# Altium schematic sheet STLink.SchDoc(STLink)
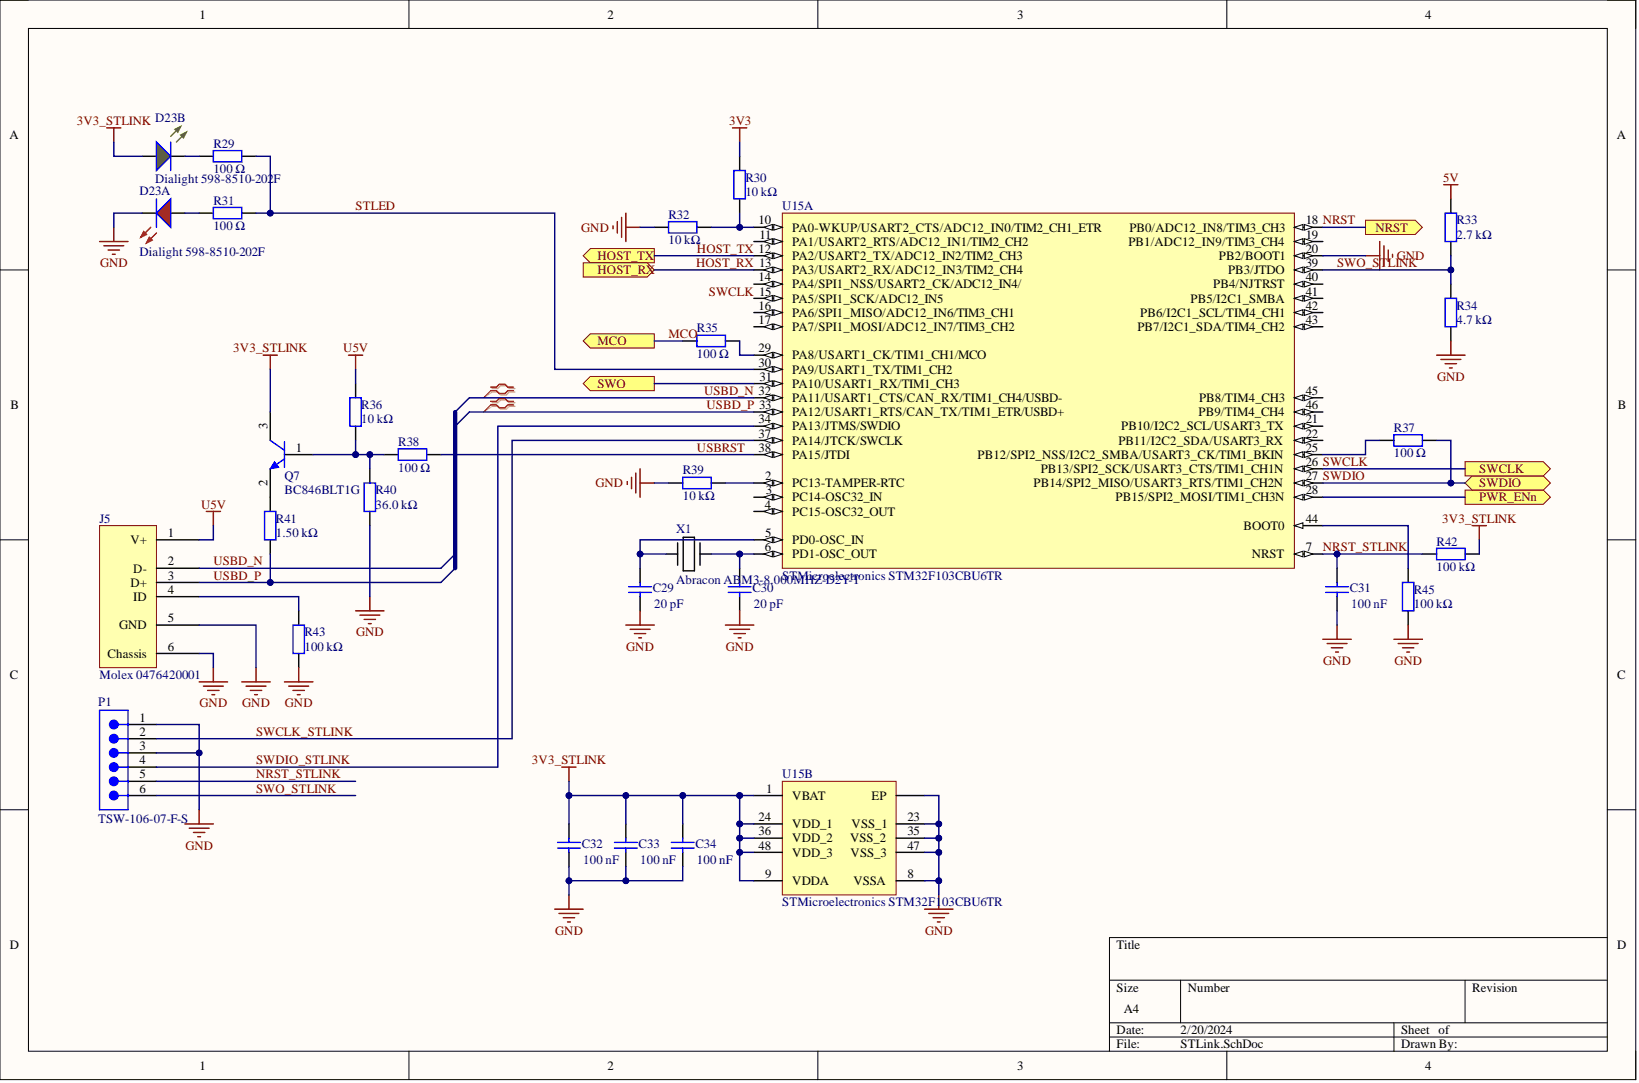
[diagram p0: STLink.SchDoc]

## Parts
C29  Capacitance: 20 pF  [PCB-gvhn8eevp3bcfemrj54e-1]  (hidden comment: Yageo CC0603JRNPO7BN200)
C30  Capacitance: 20 pF  [PCB-gvhn8eevp3bcfemrj54e-1]  (hidden comment: Yageo CC0603JRNPO7BN200)
C31  Capacitance: 100 nF  (hidden comment: Yageo CC0603ZRY5V8BB104)
C32  Yageo CC0603ZRY5V8BB104  (value not drawn)
C33  Capacitance: 100 nF  (hidden comment: Yageo CC0603ZRY5V8BB104)
C34  Capacitance: 100 nF  (hidden comment: Yageo CC0603ZRY5V8BB104)
D23  Dialight 598-8510-202F  Manufacturer Part Number: 598-8510-202F  (parts A,B)
J5  Molex 0476420001  Manufacturer Part Number: 0476420001
P1  TSW-106-07-F-S
Q7  Manufacturer Part Number: BC846BLT1G  [PCB-0002-02603-1]  (hidden comment: ON Semiconductor BC846BLT1G)
R29  Resistance: 100 Ω  (hidden comment: Yageo RC0603FR-07100RL)
R30  Resistance: 10 kΩ  (hidden comment: Yageo RC0603FR-0710KL)
R31  Resistance: 100 Ω  (hidden comment: Yageo RC0603FR-07100RL)
R32  Resistance: 10 kΩ  (hidden comment: Yageo RC0603FR-0710KL)
R33  Resistance: 2.7 kΩ  (hidden comment: Yageo RC0603FR-102K7L)
R34  Resistance: 4.7 kΩ  (hidden comment: Yageo RC0603FR-104K7L)
R35  Resistance: 100 Ω  (hidden comment: Yageo RC0603FR-07100RL)
R36  Resistance: 10 kΩ  (hidden comment: Yageo RC0603FR-0710KL)
R37  Resistance: 100 Ω  (hidden comment: Yageo RC0603FR-07100RL)
R38  Resistance: 100 Ω  (hidden comment: Yageo RC0603FR-07100RL)
R39  Resistance: 10 kΩ  (hidden comment: Yageo RC0603FR-0710KL)
R40  Yageo RC0603FR-0736KL  (value not drawn)
R41  Yageo RC0603FR-071K5L  (value not drawn)
R42  Resistance: 100 kΩ  (hidden comment: Yageo RC0603FR-07100KL)
R43  Resistance: 100 kΩ  (hidden comment: Yageo RC0603FR-07100KL)
R45  Resistance: 100 kΩ  (hidden comment: Yageo RC0603FR-07100KL)
U15  STMicroelectronics STM32F103CBU6TR  Manufacturer Part Number: STM32F103CBU6TR  (parts A,B)
X1  Abracon ABM3-8.000MHZ-D2Y-T  [PCB-goeqo0oliip49mp0922q-4]

## Ports
HOST_RX, HOST_TX, MCO, NRST, PWR_ENn, SWCLK, SWDIO, SWO

## Nets
3V3: R30.2
3V3_STLINK: C32.1 C33.1 C34.1 D23.1 Q7.3 R42.2 U15.1(VBAT) U15.9(VDDA) U15.24(VDD_1) U15.36(VDD_2) U15.48(VDD_3)
5V: R33.2
GND: C29.2 C30.2 C31.2 C32.2 C33.2 C34.2 D23.4 J5.5(GND) J5.6(Chassis) P1.1 P1.3 R32.1 R34.1 R39.1 R40.1 R43.1 R45.1 U15.8(VSSA) U15.20(PB2/BOOT1) U15.23(VSS_1) U15.35(VSS_2) U15.47(VSS_3) U15.49(EP)
U5V: J5.1(V+) R36.2
HOST_RX: U15.13(PA3/USART2_RX/ADC12_IN3/TIM2_CH4) ; port HOST_RX
HOST_TX: U15.12(PA2/USART2_TX/ADC12_IN2/TIM2_CH3) ; port HOST_TX
MCO: R35.1 ; port MCO
NRST: U15.18(PB0/ADC12_IN8/TIM3_CH3) ; port NRST
NRST_STLINK: C31.1 P1.5 R42.1 U15.7(NRST)
PWR_ENn: U15.28(PB15/SPI2_MOSI/TIM1_CH3N) ; port PWR_ENn
STLED: R29.2 R31.2 U15.30(PA9/USART1_TX/TIM1_CH2)
SWCLK: U15.15(PA5/SPI1_SCK/ADC12_IN5) U15.26(PB13/SPI2_SCK/USART3_CTS/TIM1_CH1N) ; port SWCLK
SWCLK_STLINK: P1.2 U15.37(PA14/JTCK/SWCLK)
SWDIO: R37.2 U15.27(PB14/SPI2_MISO/USART3_RTS/TIM1_CH2N) ; port SWDIO
SWDIO_STLINK: P1.4 U15.34(PA13/JTMS/SWDIO)
SWO: U15.31(PA10/USART1_RX/TIM1_CH3) ; port SWO
SWO_STLINK: P1.6 R33.1 R34.2 U15.39(PB3/JTDO)
USBD_N: J5.2(D-) U15.32(PA11/USART1_CTS/CAN_RX/TIM1_CH4/USBD-)
USBD_P: J5.3(D+) R41.1 U15.33(PA12/USART1_RTS/CAN_TX/TIM1_ETR/USBD+)
USBRST: R38.1 U15.38(PA15/JTDI)
NetC29_1: C29.1 U15.5(PD0-OSC_IN) X1.1
NetC30_1: C30.1 U15.6(PD1-OSC_OUT) X1.2
NetD23_2: D23.2 R29.1
NetD23_3: D23.3 R31.1
NetJ5_4: J5.4(ID) R43.2
NetQ7_1: Q7.1 R36.1 R38.2 R40.2
NetQ7_2: Q7.2 R41.2
NetR30_1: R30.1 R32.2 U15.10(PA0-WKUP/USART2_CTS/ADC12_IN0/TIM2_CH1_ETR)
NetR35_2: R35.2 U15.29(PA8/USART1_CK/TIM1_CH1/MCO)
NetR37_1: R37.1 U15.25(PB12/SPI2_NSS/I2C2_SMBA/USART3_CK/TIM1_BKIN)
NetR39_2: R39.2 U15.2(PC13-TAMPER-RTC)
NetR45_2: R45.2 U15.44(BOOT0)

Not connected: U15 3(PC14-OSC32_IN) 4(PC15-OSC32_OUT) 11(PA1/USART2_RTS/ADC12_IN1/TIM2_CH2) 14(PA4/SPI1_NSS/USART2_CK/ADC12_IN4/) 16(PA6/SPI1_MISO/ADC12_IN6/TIM3_CH1) 17(PA7/SPI1_MOSI/ADC12_IN7/TIM3_CH2) 19(PB1/ADC12_IN9/TIM3_CH4) 21(PB10/I2C2_SCL/USART3_TX) 22(PB11/I2C2_SDA/USART3_RX) 40(PB4/NJTRST) 41(PB5/I2C1_SMBA) 42(PB6/I2C1_SCL/TIM4_CH1) 43(PB7/I2C1_SDA/TIM4_CH2) 45(PB8/TIM4_CH3) 46(PB9/TIM4_CH4)

## Library data of the parts
C29 C30 = CMP-f2f83b8d074c2d3f-7: 0603 20pF 16 V ±10 % Tolerance X5R SMT Multilayer Ceramic Capacitor; Capacitance Tolerance: ±10%; Lead-Free Status: Lead Free; Voltage Rating (DC): 16.0 V; Case/Package: 0603, 1608; Component Kind: Standard; Dielectric Characteristic: X5R; Library Name: My Personal Space; Manufacturer Part Number: CC0603JRNPO7BN200; Mounting Style: Surface Mount; Number of Pins: 2; Octopart Url: http://octopart.com/cc0603krx5r7bb105-yageo-8376530; OctopartID: f2f83b8d074c2d3f; Packaging: Cut Tape (CT); RoHS: Compliant; Seller Landing  Url: https://octopart-clicks.com/click/track?country=US&ak=8157f166&sig=0aaebbd&sid=1106&ppid=8376530&vpid=198824008&ct=offers
C31 C33 C34 = CMP-f2f83b8d074c2d3f-7: 0603 100 nF 25 V +80%/-20% Tolerance Y5V SMT Multilayer Ceramic Capacitor; Lead-Free Status: Lead Free; Voltage Rating (DC): 25 V; Capacitance Tolerance: +80%/-20%; Case/Package: 0603, 1608; Component Kind: Standard; Dielectric Characteristic: Y5V; Library Name: My Personal Space; Manufacturer Part Number: CC0603ZRY5V8BB104; Mounting Style: Surface Mount; Number of Pins: 2; Octopart Url: http://octopart.com/cc0603krx5r7bb105-yageo-8376530; OctopartID: f2f83b8d074c2d3f; Packaging: Cut Tape (CT); RoHS: Compliant; Seller Landing  Url: https://octopart-clicks.com/click/track?country=US&ak=8157f166&sig=0aaebbd&sid=1106&ppid=8376530&vpid=198824008&ct=offers
C32 = CMP-f2f83b8d074c2d3f-7: 0603 100 nF 25 V +80%/-20% Tolerance Y5V SMT Multilayer Ceramic Capacitor; Capacitance: 100 nF; Lead-Free Status: Lead Free; Voltage Rating (DC): 25 V; Capacitance Tolerance: +80%/-20%; Case/Package: 0603, 1608; Component Kind: Standard; Dielectric Characteristic: Y5V; Library Name: My Personal Space; Manufacturer Part Number: CC0603ZRY5V8BB104; Mounting Style: Surface Mount; Number of Pins: 2; Octopart Url: http://octopart.com/cc0603krx5r7bb105-yageo-8376530; OctopartID: f2f83b8d074c2d3f; Packaging: Cut Tape (CT); RoHS: Compliant; Seller Landing  Url: https://octopart-clicks.com/click/track?country=US&ak=8157f166&sig=0aaebbd&sid=1106&ppid=8376530&vpid=198824008&ct=offers
D23 = CMP-6379aa2ba535bcbe-1: 1208 SMT Led, Red/green, Alingap , Water Clear Lens, 160 Deg Viewing Angle; Color: Red, Green; Forward Voltage: 2.00 V; Lens Color: Red; Luminous Intensity: 80.0 mcd; Viewing Angle: 160 °; Wavelength: 645 nm; Component Kind: Standard; Library Name: My Personal Space; Mounting Style: Surface Mount; Number of Pins: 4; Octopart Url: https://octopart.com/598-8510-202f-dialight-5825322; OctopartID: 6379aa2ba535bcbe; Operating Temperature: -40.0 °C to 100 °C; RoHS: Compliant
J5 = CMP-fdc035df56a02207-2: USB - micro B Receptacle Connector 5 Position Board Cutout, Surface Mount, Right Angle; Manufacturer: Molex, LLC; Manufacturer Part Number, Other: WM1398CT; Vendor Part Number: WM1398CT-ND; Vendor: Digikey; Component Kind: Standard; Connector Type: USB - micro B; Cost: 0.81; Gender: Receptacle; HelpURL: http://www.molex.com/pdm_docs/sd/476420001_sd.pdf; Library Name: CommunityVault; Mounting Type: Board Cutout, Surface Mount, Right Angle; Octopart URL: http://octopart.com/0476420001-molex-18189830; OctopartID: fdc035df56a02207
P1 = CMP-668758-2: 0.025" SQ Post Header, Through-hole, Vertical, -55 to 125 degC, 2.54 mm Pitch, 6-Pin, Male, RoHS; Number of Contacts: 6; Pin Pitch: 0.00254 m; Component Kind: Standard; Contact Material: Phosphor Bronze; Contact Plating: Gold; Gender: Male; Library Name: My Personal Space; Manufacturer Part Number: TSW-106-07-F-S; Mounting Style: Through Hole; Number of Rows: 1; Octopart Url: https://octopart.com/tsw-106-07-f-s-samtec-10311208; OctopartID: 34dafd4d4794eb1d; REACH SVHC Compliance: No SVHC; RoHS: Compliant
Q7 = CMP-7261592-4: ON SEMI# BC846BLT1G, BC S eries 65 V 100 mA SMT NPN Silicon General Purpose Transistor - SOT-23, ROHS; Breakdown Voltage [Collector to Emitter]: 65.0 V; Current Rating: 100 mA; Lead-Free Status: Lead Free; Polarity: NPN, N-Channel; Power Dissipation: 300 mW; Case/Package: SOT-23; Component Kind: Standard; Halogen Free Status: Halogen Free; Library Name: My Personal Space; Lifecycle Status: Active; Mounting Style: Surface Mount; Number of Pins: 3; Octopart URL: http://octopart.com/bc846blt1g-on+semiconductor-354341; OctopartID: ab8e6281e6cd7449; Packaging: Tape & Reel (TR), Cut Tape (CT); REACH SVHC Compliance: No SVHC; RoHS: Compliant; Voltage Rating (DC): 65.0 V
R29 R31 R35 R37 R38 = CMP-9abf51c576ad4578-19: Res General Purpose Thick Film 0603 100 Ohm 1% 1/10W ±100ppm/°C Molded Paper T/R; Case/Package (SI): 1608; Lead-Free Status: Lead Free; Power Rating: 100 mW; Temperature Coefficient: -100 ppm/°C to 100 ppm/°C; Voltage Rating (DC): 75.0 V, 50.0 V; Case/Package: 0603; Component Kind: Standard; Library Name: CommunityVault; Manufacturer Part Number: RC0603FR-07100RL; Mounting Style: Surface Mount; Number of Pins: 2; Octopart URL: https://octopart.com/rc0603fr-07100kl-yageo-40946036; OctopartID: 9abf51c576ad4578; Operating Temperature: 155 °C (max); Packaging: Tape & Reel; REACH SVHC Compliance: No SVHC; Resistance Tolerance: ±1%; RoHS: Compliant; Size-Height: 450 µm; Size-Length: 1.60 mm; Size-Width: 800 µm
R30 R32 R36 R39 = CMP-9abf51c576ad4578-19: Res General Purpose Thick Film 0603 10k Ohm 1% 1/10W ±100ppm/°C Molded Paper T/R; Case/Package (SI): 1608; Lead-Free Status: Lead Free; Power Rating: 100 mW; Temperature Coefficient: -100 ppm/°C to 100 ppm/°C; Voltage Rating (DC): 75.0 V, 50.0 V; Case/Package: 0603; Component Kind: Standard; Library Name: CommunityVault; Manufacturer Part Number: RC0603FR-0710KL; Mounting Style: Surface Mount; Number of Pins: 2; Octopart URL: https://octopart.com/rc0603fr-07100kl-yageo-40946036; OctopartID: 9abf51c576ad4578; Operating Temperature: 155 °C (max); Packaging: Tape & Reel; REACH SVHC Compliance: No SVHC; Resistance Tolerance: ±1%; RoHS: Compliant; Size-Height: 450 µm; Size-Length: 1.60 mm; Size-Width: 800 µm
R33 = CMP-9abf51c576ad4578-19: Res General Purpose Thick Film 0603 2.7k Ohm 1% 1/10W ±100ppm/°C Molded Paper T/R; Case/Package (SI): 1608; Lead-Free Status: Lead Free; Power Rating: 100 mW; Temperature Coefficient: -100 ppm/°C to 100 ppm/°C; Voltage Rating (DC): 75.0 V, 50.0 V; Case/Package: 0603; Component Kind: Standard; Library Name: CommunityVault; Manufacturer Part Number: RC0603FR-102K7L; Mounting Style: Surface Mount; Number of Pins: 2; Octopart URL: https://octopart.com/rc0603fr-07100kl-yageo-40946036; OctopartID: 9abf51c576ad4578; Operating Temperature: 155 °C (max); Packaging: Tape & Reel; REACH SVHC Compliance: No SVHC; Resistance Tolerance: ±1%; RoHS: Compliant; Size-Height: 450 µm; Size-Length: 1.60 mm; Size-Width: 800 µm
R34 = CMP-9abf51c576ad4578-19: Res General Purpose Thick Film 0603 4.7k Ohm 1% 1/10W ±100ppm/°C Molded Paper T/R; Case/Package (SI): 1608; Lead-Free Status: Lead Free; Power Rating: 100 mW; Temperature Coefficient: -100 ppm/°C to 100 ppm/°C; Voltage Rating (DC): 75.0 V, 50.0 V; Case/Package: 0603; Component Kind: Standard; Library Name: CommunityVault; Manufacturer Part Number: RC0603FR-104K7L; Mounting Style: Surface Mount; Number of Pins: 2; Octopart URL: https://octopart.com/rc0603fr-07100kl-yageo-40946036; OctopartID: 9abf51c576ad4578; Operating Temperature: 155 °C (max); Packaging: Tape & Reel; REACH SVHC Compliance: No SVHC; Resistance Tolerance: ±1%; RoHS: Compliant; Size-Height: 450 µm; Size-Length: 1.60 mm; Size-Width: 800 µm
R40 = CMP-9abf51c576ad4578-19: Res General Purpose Thick Film 0603 36k Ohm 1% 1/10W ±100ppm/°C Molded Paper T/R; Case/Package (SI): 1608; Lead-Free Status: Lead Free; Power Rating: 100 mW; Resistance: 36.0 kΩ; Temperature Coefficient: -100 ppm/°C to 100 ppm/°C; Voltage Rating (DC): 75.0 V, 50.0 V; Case/Package: 0603; Component Kind: Standard; Library Name: CommunityVault; Manufacturer Part Number: RC0603FR-0736KL; Mounting Style: Surface Mount; Number of Pins: 2; Octopart URL: https://octopart.com/rc0603fr-07100kl-yageo-40946036; OctopartID: 9abf51c576ad4578; Operating Temperature: 155 °C (max); Packaging: Tape & Reel; REACH SVHC Compliance: No SVHC; Resistance Tolerance: ±1%; RoHS: Compliant; Size-Height: 450 µm; Size-Length: 1.60 mm; Size-Width: 800 µm
R41 = CMP-9abf51c576ad4578-19: Res General Purpose Thick Film 0603 1.5k Ohm 1% 1/10W ±100ppm/°C Molded Paper T/R; Manufacturer Part Number: RC0603FR-071.5KL; Case/Package (SI): 1608; Lead-Free Status: Lead Free; Power Rating: 100 mW; Resistance: 1.50 kΩ; Temperature Coefficient: -100 ppm/°C to 100 ppm/°C; Voltage Rating (DC): 75.0 V, 50.0 V; Case/Package: 0603; Component Kind: Standard; Library Name: CommunityVault; Mounting Style: Surface Mount; Number of Pins: 2; Octopart URL: https://octopart.com/rc0603fr-07100kl-yageo-40946036; OctopartID: 9abf51c576ad4578; Operating Temperature: 155 °C (max); Packaging: Tape & Reel; REACH SVHC Compliance: No SVHC; Resistance Tolerance: ±1%; RoHS: Compliant; Size-Height: 450 µm; Size-Length: 1.60 mm; Size-Width: 800 µm
R42 R43 R45 = CMP-9abf51c576ad4578-19: Res General Purpose Thick Film 0603 100k Ohm 1% 1/10W ±100ppm/°C Molded Paper T/R; Case/Package (SI): 1608; Lead-Free Status: Lead Free; Power Rating: 100 mW; Temperature Coefficient: -100 ppm/°C to 100 ppm/°C; Voltage Rating (DC): 75.0 V, 50.0 V; Case/Package: 0603; Component Kind: Standard; Library Name: CommunityVault; Manufacturer Part Number: RC0603FR-07100KL; Mounting Style: Surface Mount; Number of Pins: 2; Octopart URL: https://octopart.com/rc0603fr-07100kl-yageo-40946036; OctopartID: 9abf51c576ad4578; Operating Temperature: 155 °C (max); Packaging: Tape & Reel; REACH SVHC Compliance: No SVHC; Resistance Tolerance: ±1%; RoHS: Compliant; Size-Height: 450 µm; Size-Length: 1.60 mm; Size-Width: 800 µm
U15 = CMP-a915624c986476d2-1: MCU 32-Bit STM32 ARM Cortex M3 RISC 128KB Flash 2.5V/3.3V 48-Pin UFQFPN T/R; Component Kind: Standard; Library Name: My Personal Space; Lifecycle Status: Active; Octopart Url: https://octopart.com/stm32f103cbu6tr-stmicroelectronics-38999063; OctopartID: a915624c986476d2; Packaging: Tape & Reel (TR)
X1 = CMP-783581-13: Crystal 8MHz 20ppm (Tol) 30ppm (Stability) 18pF FUND 500Ohm 2-Pin Mini-CSMD T/R; Equivalent Series Resistance (ESR): 500 Ω; Frequency: 8.00 MHz; Lead-Free Status: Lead Free; Load Capacitance: 18.0 pF; Component Kind: Standard; Library Name: My Personal Space; Manufacturer Part Number: ABM3-8.000MHZ-D2Y-T; Mounting Style: Surface Mount; Number of Pins: 2; Octopart URL: https://octopart.com/abm3-8.000mhz-d2y-t-abracon-18618803; OctopartID: 025fca493e8add98; Operating Temperature: -40.0 °C to 85.0 °C; Packaging: Cut Tape (CT); REACH SVHC Compliance: Contains SVHC; RoHS: Compliant
